# Altium schematic sheet Alimentations.SchDoc
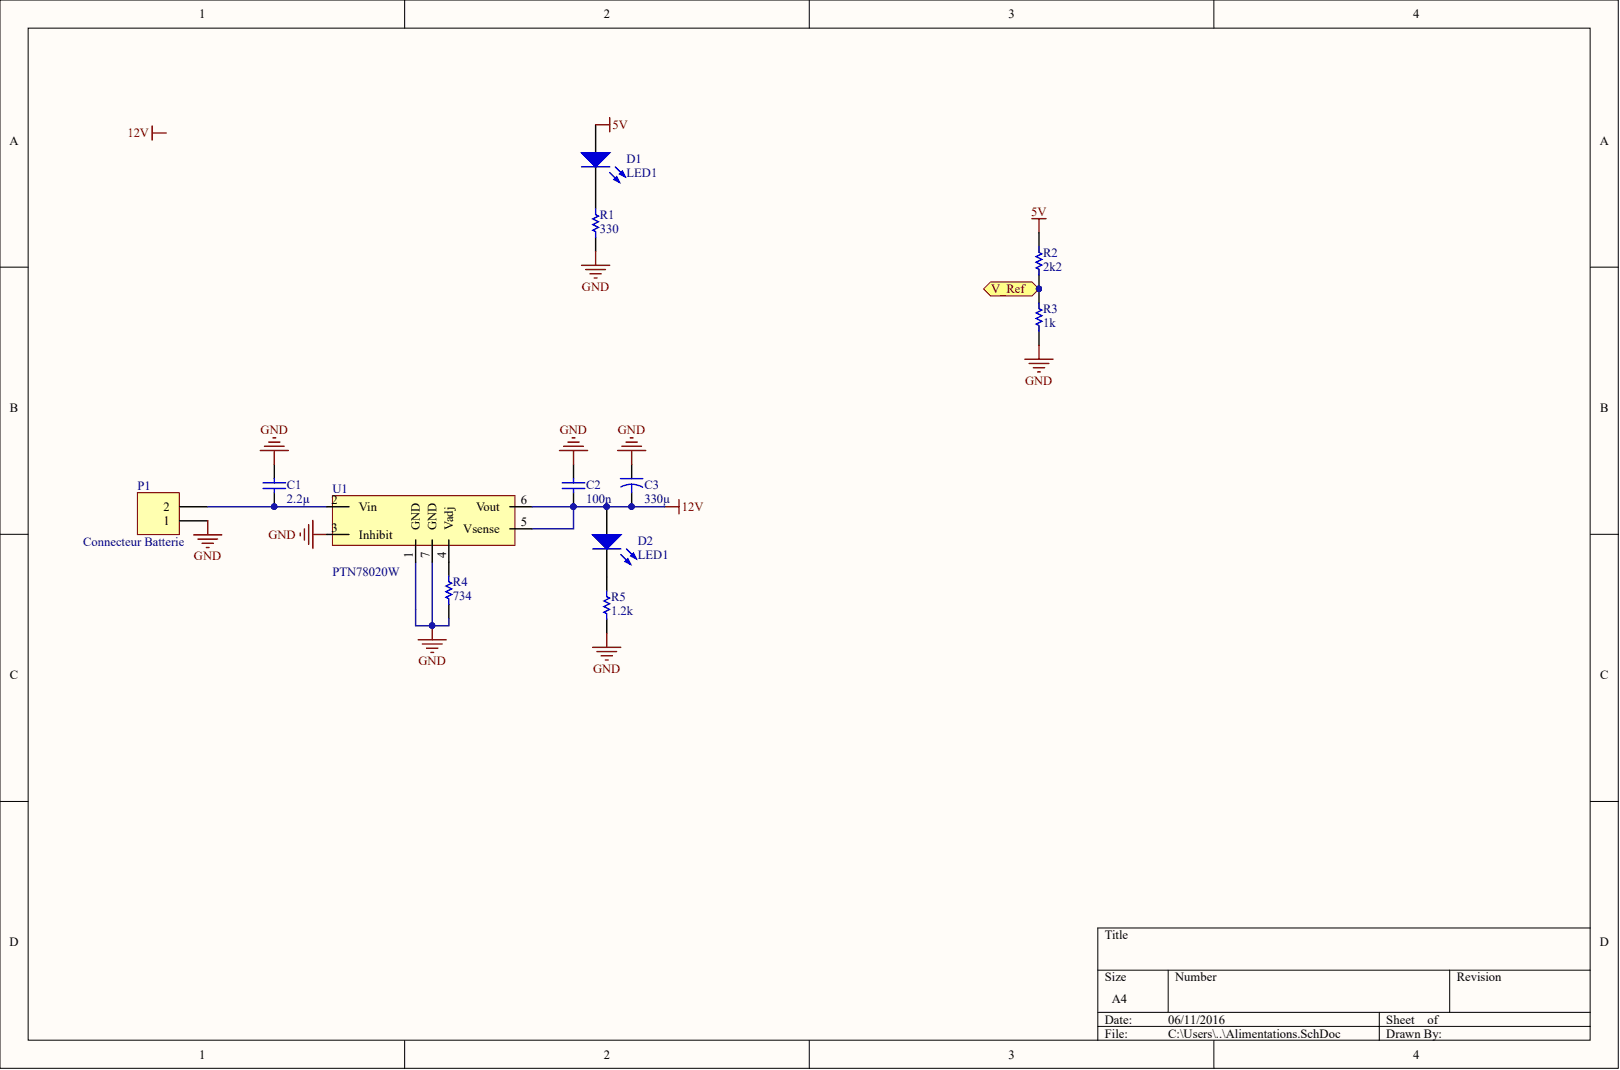
[diagram p0: Alimentations.SchDoc]

## Parts
C1  value 2.2µ  [RAD-0.3]  (hidden comment: Cap)
C2  value 100n  [RAD-0.3]  (hidden comment: Cap)
C3  value 330µ  [CAPR5-4X5]  (hidden comment: Cap2)
D1  LED1  [C0805]
D2  LED1  [C0805]
P1  Connecteur Batterie  [HDR1X2]
R1  value 330  [AXIAL-0.3]  (hidden comment: Res1)
R2  value 2k2  [AXIAL-0.3]  (hidden comment: Res1)
R3  value 1k  [AXIAL-0.3]  (hidden comment: Res1)
R4  value 734  [AXIAL-0.3]  (hidden comment: Res1)
R5  value 1.2k  [AXIAL-0.3]  (hidden comment: Res1)
U1  PTN78020W

## Ports
V_REF

## Nets
5V: D1.1 R2.1
12V: C2.1 C3.2 D2.1 U1.5(Vsense) U1.6(Vout)
GND: C1.2 C2.2 C3.1 P1.1 R1.2 R3.2 R4.1 R5.2 U1.1(GND) U1.3(Inhibit) U1.7(GND)
NetC1_1: C1.1 P1.2 U1.2(Vin)
NetD1_2: D1.2 R1.1
NetD2_2: D2.2 R5.1
NetR2_2: R2.2 R3.1 ; port V_REF
NetR4_2: R4.2 U1.4(Vadj)

## Library data of the parts
C1 C2 = Cap: Capacitor; Component Kind: Standard; LatestRevisionDate: 17-Jul-2002; LatestRevisionNote: Re-released for DXP Platform.; Library Name: Miscellaneous Devices.IntLib; PackageReference: RAD-0.3; Published: 8-Jun-2000; Signal Integrity: Cap; Simulation: CAP; Publisher: Altium Limited
C3 = Cap2: Capacitor; Component Kind: Standard; Library Name: Miscellaneous Devices.IntLib; PackageReference: CAPR5-4X5; Published: 23-Sep-2002; Signal Integrity: Cap; Simulation: CAP; Publisher: Altium Limited
D1 D2 = LED1: Typical RED GaAs LED; Component Kind: Standard; LatestRevisionDate: 08-Jul-2005; LatestRevisionNote: Mech Layer 1 removed.; Library Name: Miscellaneous Devices.IntLib; PackageReference: LED-1; Published: 8-Jun-2000; Simulation: LED1; Publisher: Altium Limited
P1 = Header 2: Header, 2-Pin; Component Kind: Standard; LatestRevisionDate: 17-Jul-2002; LatestRevisionNote: Re-released for DXP Platform.; Library Name: Miscellaneous Connectors.IntLib; Signal Integrity: Connector; Publisher: Altium Limited
R1-R5 = Res1: Resistor; Component Kind: Standard; LatestRevisionDate: 17-Jul-2002; LatestRevisionNote: Re-released for DXP Platform.; Library Name: Miscellaneous Devices.IntLib; PackageReference: AXIAL-0.3; Published: 8-Jun-2000; Signal Integrity: Res; Simulation: RESISTOR; Publisher: Altium Limited
U1 = PTN78020W: Component Kind: Standard; Library Name: lib_Gabriel.SchLib
